# Altium schematic sheet [03] - 28PINS SCHEMATIC.SchDoc("[03] - 28PINS SCHEMATIC") (continued)

## Library data of the parts
C1 C3 C4 C7 C11 C13 C15-C17 C21 C22 C24 C26 C28-C33 = C2012X7R1H104K085AA: Multilayer Ceramic Capacitor, 0.1 uF, 50 V, ± 10%, X7R, 0805 [2012 Metric]; Manufacturer: TDK; Voltage Rating: 50V; Material: 4241ConductorMaterial; Width: 0.049inch; Voltage: 50V; Tolerance: 10%; Thickness: 0.037inch; Termination: SMD/SMT; Supplier Part Number 1: 445-7534-1-ND; Supplier 1: Digikey; Stock 1: 3235; Series: C; RoHS Compliant: Yes; RoHS: Yes; Reach SVHC Compliant: No; Pricing 1: 1 = 0.13, 10 = 0.091, 50 = 0.054, 100 = 0.0425, 500 = 0.03142, 1000 = 0.02601 (USD); Packaging: Tape and Reel; Package Quantity: 1; Number of Pins: 2; Mount: Surface Mount; Min Operating Temperature: -55°C; Max Operating Temperature: 125°C; Manufacturer Part Number: C2012X7R1H104K085AA; Library Name: 28Pins.SchLib; Length: 2mm; Lead Free: Lead Free; Height: 0.85mm; Dielectric Material: Ceramic; Dielectric: X7R; Depth: 1.25mm; ComponentLink6URL: https://datasheet.ciiva.com/24191/e412-c-24191447.pdf?src-supplier=Future+Electronics; ComponentLink6Description: https://datasheet.ciiva.com/24191/e412-c-24191447.pdf?src-supplier=Future+Electronics; ComponentLink5URL: https://datasheet.ciiva.com/6250/tdkmlcccaprange-6250824.pdf?src-supplier=Digikey; ComponentLink5Description: https://datasheet.ciiva.com/6250/tdkmlcccaprange-6250824.pdf?src-supplier=Digikey; ComponentLink4URL: https://datasheet.ciiva.com/6250/tdkmlcccaprange-6250821.pdf?src-supplier=Digikey; ComponentLink4Description: https://datasheet.ciiva.com/6250/tdkmlcccaprange-6250821.pdf?src-supplier=Digikey; ComponentLink3URL: https://datasheet.ciiva.com/28602/1571323-28602204.pdf?src-supplier=Element14; ComponentLink3Description: https://datasheet.ciiva.com/28602/1571323-28602204.pdf?src-supplier=Element14; ComponentLink2URL: https://datasheet.ciiva.com/23803/1796654-23803035.pdf?src-supplier=Newark; ComponentLink2Description: https://datasheet.ciiva.com/23803/1796654-23803035.pdf?src-supplier=Newark; ComponentLink1URL: https://datasheet.ciiva.com/11788/0900766b80c1bb00-11788128.pdf?src-supplier=Rs; ComponentLink1Description: https://datasheet.ciiva.com/11788/0900766b80c1bb00-11788128.pdf?src-supplier=Rs; Component Kind: Standard; Case Code (Metric): 2012; Case Code (Imperial): 0805; Case/Package: 0805; Capacitance: 100nF
C2 C12 C14 C18-C20 C23 = C2012X5R1C106M085AC: Multilayer Ceramic Capacitor, 10 uF, 16 V, ± 20%, X5R, 0805 [2012 Metric]; Manufacturer: TDK; Voltage Rating (DC): 16V; Material: 4241ConductorMaterial; Capacitance: 10uF; Width: 1.25mm; Weight: 0.000194oz; Voltage Rating: 16V; Voltage: 16V; Tolerance: 20%; Termination: SMD/SMT; Supplier Part Number 1: 445-7645-1-ND; Supplier 1: Digikey; Stock 1: 27909; Series: C; RoHS Compliant: Yes; RoHS: Yes; Reach SVHC Compliant: Unknown; Pricing 1: 1 = 0.26, 10 = 0.169, 50 = 0.1066, 100 = 0.0853, 500 = 0.0616, 1000 = 0.05449 (USD); Packaging: Tape and Reel; Package Quantity: 4000; Number of Pins: 2; Mount: Surface Mount; Min Operating Temperature: -55°C; Max Operating Temperature: 85°C; Manufacturer Part Number: C2012X5R1C106M085AC; Library Name: 28Pins.SchLib; Length: 2mm; Lead Free: Lead Free; Height: 0.85mm; Dielectric Material: Ceramic; Dielectric: X5R; Depth: 1.25mm; Construction: Flat; ComponentLink7URL: https://datasheet.ciiva.com/22725/1913358-22725557.pdf?src-supplier=Element14; ComponentLink7Description: https://datasheet.ciiva.com/22725/1913358-22725557.pdf?src-supplier=Element14; ComponentLink6URL: https://datasheet.ciiva.com/6290/tdkmlcccaprange-6290487.pdf?src-supplier=Digikey; ComponentLink6Description: https://datasheet.ciiva.com/6290/tdkmlcccaprange-6290487.pdf?src-supplier=Digikey; ComponentLink5URL: https://datasheet.ciiva.com/6290/tdkmlcccaprange-6290485.pdf?src-supplier=Digikey; ComponentLink5Description: https://datasheet.ciiva.com/6290/tdkmlcccaprange-6290485.pdf?src-supplier=Digikey; ComponentLink4URL: https://datasheet.ciiva.com/23803/1796654-23803021.pdf?src-supplier=Newark; ComponentLink4Description: https://datasheet.ciiva.com/23803/1796654-23803021.pdf?src-supplier=Newark; ComponentLink3URL: https://datasheet.ciiva.com/16449/e412-c-16449591.pdf?src-supplier=Future+Electronics; ComponentLink3Description: https://datasheet.ciiva.com/16449/e412-c-16449591.pdf?src-supplier=Future+Electronics; ComponentLink2URL: https://datasheet.ciiva.com/11788/0900766b80c1bb00-11788108.pdf?src-supplier=Rs; ComponentLink2Description: https://datasheet.ciiva.com/11788/0900766b80c1bb00-11788108.pdf?src-supplier=Rs; ComponentLink1URL: https://datasheet.ciiva.com/24218/c2012x5r1c106m085ac-265002-24218340.pdf?src-supplier=Mouser; ComponentLink1Description: https://datasheet.ciiva.com/24218/c2012x5r1c106m085ac-265002-24218340.pdf?src-supplier=Mouser; Component Kind: Standard; Case Code (Metric): 2012; Case Code (Imperial): 0805; Case/Package: 0805
C5 C6 = EEE-1AA101WR: Aluminum Electrolytic Capacitor, 100 uF, 10 V, ± 20%, 1000 hours @ 85°C, Radial Can - SMD; Manufacturer: Panasonic; Voltage Rating (DC): 10V; Ripple Current (AC): 50mA; Leakage Current: 10uA; Dissipation Factor: 30%; Voltage Rating: 10V; Tolerance: 20%; Termination: SMD/SMT; Supplier Part Number 1: PCE3867CT-ND; Supplier 1: Digikey; Stock 1: 10133; Series: S; RoHS Compliant: Yes; RoHS: Yes; Ripple Current: 50mA; Reach SVHC Compliant: Unknown; Ratings: AEC-Q200; Radiation Hardening: No; Pricing 1: 1 = 0.35, 10 = 0.228, 50 = 0.168, 100 = 0.1368, 500 = 0.108 (USD); Packaging: Tape and Reel; Package Quantity: 1000; Mount: Surface Mount,PCB; Min Operating Temperature: -40°C; Max Operating Temperature: 85°C; Manufacturer Part Number: EEE-1AA101WR; Life (Hours): 1000Hour; Library Name: 28Pins.SchLib; Length: 5.5mm; Lead Free: Lead Free; Height: 5.4mm; Diameter: 5mm; Construction: Cylindrical; ComponentLink8URL: https://datasheet.ciiva.com/30075/11aba0000ce2-30075303.pdf?src-supplier=Onlinecomponents.com; ComponentLink8Description: https://datasheet.ciiva.com/30075/11aba0000ce2-30075303.pdf?src-supplier=Onlinecomponents.com; ComponentLink7URL: https://datasheet.ciiva.com/2983/aba0000ce2-2983906.pdf?src-supplier=Avnet; ComponentLink7Description: https://datasheet.ciiva.com/2983/aba0000ce2-2983906.pdf?src-supplier=Avnet; ComponentLink6URL: https://datasheet.ciiva.com/16427/aba0000ce2-16427544.pdf?src-supplier=Future+Electronics; ComponentLink6Description: https://datasheet.ciiva.com/16427/aba0000ce2-16427544.pdf?src-supplier=Future+Electronics; ComponentLink5URL: https://datasheet.ciiva.com/24027/aba0000ce2-40801-24027541.pdf?src-supplier=Mouser; ComponentLink5Description: https://datasheet.ciiva.com/24027/aba0000ce2-40801-24027541.pdf?src-supplier=Mouser; ComponentLink4URL: https://datasheet.ciiva.com/11673/aba0000ce2-11673882.pdf?src-supplier=Avnet; ComponentLink4Description: https://datasheet.ciiva.com/11673/aba0000ce2-11673882.pdf?src-supplier=Avnet; ComponentLink3URL: https://datasheet.ciiva.com/14605/70255710-14605689.pdf?src-supplier=Allied+Electronics; ComponentLink3Description: https://datasheet.ciiva.com/14605/70255710-14605689.pdf?src-supplier=Allied+Electronics; ComponentLink2URL: https://datasheet.ciiva.com/14598/70255710-14598233.pdf?src-supplier=Allied+Electronics; ComponentLink2Description: https://datasheet.ciiva.com/14598/70255710-14598233.pdf?src-supplier=Allied+Electronics; ComponentLink1URL: https://datasheet.ciiva.com/26945/getdatasheetpartid-169213-26945071.pdf?src-supplier=Verical; ComponentLink1Description: https://datasheet.ciiva.com/26945/getdatasheetpartid-169213-26945071.pdf?src-supplier=Verical; Component Kind: Standard; Case/Package: Radial; Capacitance: 100uF
C8 = C2012X5R1E105K125AA: CAP CER 1UF 25V X5R 0805; Manufacturer: TDK; Tolerance: 10%; Dielectric Material: Ceramic; Voltage Rating: 25V; Voltage: 25V; Termination: SMD/SMT; Supplier Part Number 1: 445-1419-1-ND; Supplier 1: Digikey; Stock 1: 54578; Series: C; RoHS Compliant: Yes; RoHS: Yes; Reach SVHC Compliant: No; Radiation Hardening: No; Pricing 1: 1 = 0.22, 10 = 0.141, 50 = 0.089, 100 = 0.0712, 500 = 0.05142, 1000 = 0.04548 (USD); Packaging: Tape and Reel; Number of Pins: 2; Mount: Surface Mount; Min Operating Temperature: -55°C; Max Operating Temperature: 85°C; Manufacturer Part Number: C2012X5R1E105K125AA; Library Name: 28Pins.SchLib; Length: 2mm; Lead Free: Lead Free; Height: 1.25mm; Dielectric: X5R; Depth: 1.25mm; Construction: Flat; ComponentLink4URL: https://datasheet.ciiva.com/16449/e412-c-16449595.pdf?src-supplier=Future+Electronics; ComponentLink4Description: https://datasheet.ciiva.com/16449/e412-c-16449595.pdf?src-supplier=Future+Electronics; ComponentLink3URL: https://datasheet.ciiva.com/15192/getdatasheetpartid-726707-15192140.pdf?src-supplier=Verical; ComponentLink3Description: https://datasheet.ciiva.com/15192/getdatasheetpartid-726707-15192140.pdf?src-supplier=Verical; ComponentLink2URL: https://datasheet.ciiva.com/28602/1744655-28602171.pdf?src-supplier=Element14; ComponentLink2Description: https://datasheet.ciiva.com/28602/1744655-28602171.pdf?src-supplier=Element14; ComponentLink1URL: https://datasheet.ciiva.com/11787/0900766b80c1bb00-11787608.pdf?src-supplier=Rs; ComponentLink1Description: https://datasheet.ciiva.com/11787/0900766b80c1bb00-11787608.pdf?src-supplier=Rs; Component Kind: Standard; Case Code (Metric): 2012; Case Code (Imperial): 0805; Case/Package: 0805; Capacitance: 1uF
C9 C10 C25 C27 = C0805C180J5GACTU: Multilayer Ceramic Capacitor, 18 pF, 50 V, ± 5%, C0G (NP0), 0805 [2012 Metric]; Manufacturer: KEMET; Voltage Rating (DC): 50V; Material: 4241ConductorMaterial; Dissipation Factor: 0.1%; Capacitance: 18pF; Width: 0.049inch; Voltage Rating (AC): 50V; Voltage Rating: 50V; Voltage: 50V; Tolerance: 5%; Thickness: 0.035inch; Termination: SMD/SMT; Supplier Part Number 1: 399-C0805C180J5GAC7800CT-ND; Supplier 1: Digikey; Stock 1: 144471; Series: C; RoHS Compliant: Yes; RoHS: Yes; Reach SVHC Compliant: No; Radiation Hardening: No; Pricing 1: 1 = 0.11, 10 = 0.075, 50 = 0.045, 100 = 0.0355, 500 = 0.02618, 1000 = 0.02168 (USD); Packaging: Tape and Reel; Package Quantity: 1; Number of Pins: 2; Mount: Surface Mount,PCB; Min Operating Temperature: -55°C; Max Operating Temperature: 125°C; Manufacturer Part Number: C0805C180J5GACTU; Library Name: 28Pins.SchLib; Length: 2mm; Lead Pitch: 0.75mm; Lead Free: 5697LeadFree; Height: 0.78mm; Dielectric: C0G; Depth: 1.25mm; Construction: Flat; ComponentLink3URL: https://datasheet.ciiva.com/26847/getdatasheetpartid-385668-26847862.pdf?src-supplier=Verical; ComponentLink3Description: https://datasheet.ciiva.com/26847/getdatasheetpartid-385668-26847862.pdf?src-supplier=Verical; ComponentLink2URL: https://datasheet.ciiva.com/26923/getdatasheetpartid-759702-26923980.pdf?src-supplier=Verical; ComponentLink2Description: https://datasheet.ciiva.com/26923/getdatasheetpartid-759702-26923980.pdf?src-supplier=Verical; ComponentLink1URL: https://datasheet.ciiva.com/22746/1769375-22746770.pdf?src-supplier=Element14; ComponentLink1Description: https://datasheet.ciiva.com/22746/1769375-22746770.pdf?src-supplier=Element14; Component Kind: Standard; Case Code (Metric): 2012; Case Code (Imperial): 0805; Case/Package: 0805
D1-D3 = SML-311DTT86: LED Uni-Color Orange 2-Pin Chip 0603(1608Metric) T/R; Manufacturer: Rohm; Wavelength: 611nm; Viewing Angle: 140°; Test Current: 2mA; Number of LEDs: 1; Luminous Intensity: 2.5mcd; Lens Transparency: Clear; Lens Style: Rectangular,Diffused,Colorless,Clear; Lens Color: Colorless,Clear; Forward Voltage: 1.8V; Dominant Wavelength: 605nm; Width: 0.8mm; Supplier Part Number 1: 511-1303-1-ND; Supplier 1: Digikey; Stock 1: 25516; Series: -; RoHS Compliant: Yes; RoHS: Yes; Radiation Hardening: No; Pricing 1: 1 = 0.39, 10 = 0.257, 100 = 0.1717, 500 = 0.11878, 1000 = 0.09898 (USD); Packaging: Tape and Reel; Package Quantity: 1; Number of Pins: 2; Mount: Surface Mount; Min Operating Temperature: -30°C; Max Operating Temperature: 85°C; Manufacturer Part Number: SML-311DTT86; Library Name: 28Pins.SchLib; Length: 1.6mm; Lead Free: Lead Free; Illumination Color: Orange; Height: 0.9mm; Forward Current: 2mA; ComponentLink5URL: https://datasheet.ciiva.com/2110/sml-311series-2110840.pdf?src-supplier=Digikey; ComponentLink5Description: https://datasheet.ciiva.com/2110/sml-311series-2110840.pdf?src-supplier=Digikey; ComponentLink4URL: https://datasheet.ciiva.com/2110/sml-311series-2110839.pdf?src-supplier=Digikey; ComponentLink4Description: https://datasheet.ciiva.com/2110/sml-311series-2110839.pdf?src-supplier=Digikey; ComponentLink3URL: https://datasheet.ciiva.com/2110/sml-311series-2110838.pdf?src-supplier=Digikey; ComponentLink3Description: https://datasheet.ciiva.com/2110/sml-311series-2110838.pdf?src-supplier=Digikey; ComponentLink2URL: https://datasheet.ciiva.com/16388/sml-31-16388237.pdf?src-supplier=Future+Electronics; ComponentLink2Description: https://datasheet.ciiva.com/16388/sml-31-16388237.pdf?src-supplier=Future+Electronics; ComponentLink1URL: https://datasheet.ciiva.com/11774/0900766b80df3a77-11774225.pdf?src-supplier=Rs; ComponentLink1Description: https://datasheet.ciiva.com/11774/0900766b80df3a77-11774225.pdf?src-supplier=Rs; Component Kind: Standard; Color: Orange; Case Code (Metric): 1608; Case Code (Imperial): 0603; Case/Package: 0603
D4 = APT1608LZGCK: Green 525nm LED Indication - Discrete 2.65V 0603 (1608 Metric); Manufacturer: Kingbright; Viewing Angle: 120°; Test Current: 2mA; Luminous Intensity: 50mcd; Lens Transparency: Clear; Lens Color: Colorless; Width: 0.8mm; Supplier Part Number 1: 754-1934-1-ND; Supplier 1: Digikey; Stock 1: 73571; Series: HELI2; RoHS Compliant: Yes; RoHS: Yes; Pricing 1: 1 = 0.55, 10 = 0.364, 100 = 0.241, 1000 = 0.1861 (USD); Packaging: Cut Tape; Mount: Surface Mount; Manufacturer Part Number: APT1608LZGCK; Library Name: 28Pins.SchLib; Length: 1.6mm; Height: 0.75mm; Forward Voltage: 2.65V; Dominant Wavelength: 525nm; ComponentLink2URL: http://www.kingbrightusa.com/images/catalog/SPEC/APT1608LZGCK.pdf?src-supplier=Digikey; ComponentLink2Description: http://www.kingbrightusa.com/images/catalog/SPEC/APT1608LZGCK.pdf?src-supplier=Digikey; ComponentLink1URL: http://www.mouser.com/ds/2/216/APT1608LZGCK-535830.pdf?src-supplier=Mouser; ComponentLink1Description: http://www.mouser.com/ds/2/216/APT1608LZGCK-535830.pdf?src-supplier=Mouser; Component Kind: Standard; Color: Green; Case Code (Metric): 1608; Case Code (Imperial): 0603; Case/Package: 0603
D5 D6 = BAT54HT1G: Small Signal Schottky Diode, Single, 30 V, 200 Ma, 800 Mv, 600 Ma, 150 C; Manufacturer: ON Semiconductor / Fairchild; Voltage Rating (DC): 30V; Reverse Recovery Time-Max: 5ns; Power Dissipation: 200mW; Polarity: Standard; Peak Non-Repetitive Surge Current: 600mA; Output Current: 200mA; Max Reverse Leakage Current: 2uA; Forward Voltage: 800mV; Capacitance: 10pF; Width: 1.35mm; Supplier Part Number 1: BAT54HT1GOSCT-ND; Supplier 1: Digikey; Stock 1: 8229; Series: -; RoHS Compliant: Yes; RoHS: Yes; Reverse Voltage: 30V; Reverse Recovery Time: 5ns; Recovery Time: 5ns; Reach SVHC Compliant: No; Radiation Hardening: No; Pricing 1: 1 = 0.2, 10 = 0.138, 100 = 0.0675, 500 = 0.05634, 1000 = 0.03915 (USD); Packaging: Tape and Reel; Package Quantity: 1; Number of Pins: 2; Mount: Surface Mount; Min Operating Temperature: -55°C; Max Surge Current: 600mA; Max Reverse Voltage (DC): 30V; Max Reverse Current: 2uA; Max Repetitive Reverse Voltage (Vrrm): 30V; Max Operating Temperature: 150°C; Max Forward Surge Current (Ifsm): 600mA; Manufacturer Part Number: BAT54HT1G; Library Name: 28Pins.SchLib; Length: 1.8mm; Lead Free: Lead Free; Height: 1mm; Forward Current: 200mA; Current Rating: 200mA; Contact Plating: Tin,Matte; ComponentLink18URL: https://datasheet.ciiva.com/48686/001262925-da-01-en-diode-schottky-30v-bat54ht1g-sod-323-fsc-48686266.pdf?src-supplier=Conrad; ComponentLink18Description: https://datasheet.ciiva.com/48686/001262925-da-01-en-diode-schottky-30v-bat54ht1g-sod-323-fsc-48686266.pdf?src-supplier=Conrad; ComponentLink17URL: https://datasheet.ciiva.com/17020/bat54ht1g-17020445.pdf?src-supplier=Future+Electronics; ComponentLink17Description: https://datasheet.ciiva.com/17020/bat54ht1g-17020445.pdf?src-supplier=Future+Electronics; ComponentLink16URL: https://datasheet.ciiva.com/1180/bat54ht1-d-1180251.pdf?src-supplier=Element14; ComponentLink16Description: https://datasheet.ciiva.com/1180/bat54ht1-d-1180251.pdf?src-supplier=Element14; ComponentLink15URL: https://datasheet.ciiva.com/1180/bat54ht1-d-1180252.pdf?src-supplier=Element14; ComponentLink15Description: https://datasheet.ciiva.com/1180/bat54ht1-d-1180252.pdf?src-supplier=Element14; ComponentLink14URL: https://datasheet.ciiva.com/46429/1727620-46429206.pdf?src-supplier=Element14; ComponentLink14Description: https://datasheet.ciiva.com/46429/1727620-46429206.pdf?src-supplier=Element14; ComponentLink13URL: https://datasheet.ciiva.com/915/bat54ht1-d-915443.pdf?src-supplier=Digikey; ComponentLink13Description: https://datasheet.ciiva.com/915/bat54ht1-d-915443.pdf?src-supplier=Digikey; ComponentLink12URL: https://datasheet.ciiva.com/8303/bat54ht1g-8303645.pdf?src-supplier=Avnet; ComponentLink12Description: https://datasheet.ciiva.com/8303/bat54ht1g-8303645.pdf?src-supplier=Avnet; ComponentLink11URL: https://datasheet.ciiva.com/910/bat54ht1g-910497.pdf?src-supplier=Digikey; ComponentLink11Description: https://datasheet.ciiva.com/910/bat54ht1g-910497.pdf?src-supplier=Digikey; ComponentLink10URL: https://datasheet.ciiva.com/8294/bat54ht1-d-8294645.pdf?src-supplier=Avnet; ComponentLink10Description: https://datasheet.ciiva.com/8294/bat54ht1-d-8294645.pdf?src-supplier=Avnet; ComponentLink9URL: https://datasheet.ciiva.com/910/bat54ht1g-910498.pdf?src-supplier=Digikey; ComponentLink9Description: https://datasheet.ciiva.com/910/bat54ht1g-910498.pdf?src-supplier=Digikey; ComponentLink8URL: https://datasheet.ciiva.com/910/bat54ht1g-910499.pdf?src-supplier=Digikey; ComponentLink8Description: https://datasheet.ciiva.com/910/bat54ht1g-910499.pdf?src-supplier=Digikey; ComponentLink7URL: https://datasheet.ciiva.com/915/bat54ht1-d-915444.pdf?src-supplier=Digikey; ComponentLink7Description: https://datasheet.ciiva.com/915/bat54ht1-d-915444.pdf?src-supplier=Digikey; ComponentLink6URL: https://datasheet.ciiva.com/915/bat54ht1-d-915445.pdf?src-supplier=Digikey; ComponentLink6Description: https://datasheet.ciiva.com/915/bat54ht1-d-915445.pdf?src-supplier=Digikey; ComponentLink5URL: https://datasheet.ciiva.com/1240/1747983-1240762.pdf?src-supplier=Newark; ComponentLink5Description: https://datasheet.ciiva.com/1240/1747983-1240762.pdf?src-supplier=Newark; ComponentLink4URL: https://datasheet.ciiva.com/34301/806549-34301562.pdf?src-supplier=Jameco; ComponentLink4Description: https://datasheet.ciiva.com/34301/806549-34301562.pdf?src-supplier=Jameco; ComponentLink3URL: https://datasheet.ciiva.com/30479/0900766b811a63a9-30479442.pdf?src-supplier=Rs; ComponentLink3Description: https://datasheet.ciiva.com/30479/0900766b811a63a9-30479442.pdf?src-supplier=Rs; ComponentLink2URL: https://datasheet.ciiva.com/4452/bat54ht1g-104602-4452889.pdf?src-supplier=Mouser; ComponentLink2Description: https://datasheet.ciiva.com/4452/bat54ht1g-104602-4452889.pdf?src-supplier=Mouser; ComponentLink1URL: https://datasheet.ciiva.com/4714/bat54ht1-d-72881-4714706.pdf?src-supplier=Mouser; ComponentLink1Description: https://datasheet.ciiva.com/4714/bat54ht1-d-72881-4714706.pdf?src-supplier=Mouser; Component Kind: Standard; Breakdown Voltage: 30V; Average Rectified Current: 200mA
FB1-FB3 = MPZ2012S601AT000: Ferrite Beads Multi-Layer Power 600Ohm 25% 100MHz 2A 100mOhm DCR 0805 T/R; Manufacturer: TDK; Series Resistance: 100mR; Number of Lines: 1; Impedance: 600R; Width: 0.049inch; Tolerance: 25%; Test Frequency: 100MHz; Supplier Part Number 1: 1131051; Supplier 1: Future Electronics; Stock 1: 524000; Series: MPZ; RoHS Compliant: Yes; RoHS: Yes; RMS Current (Irms): 2A; Reach SVHC Compliant: No; Pricing 1: 4000 = 0.0289, 12000 = 0.0278, 20000 = 0.0273, 40000 = 0.0267, 80000 = 0.0257 (USD); Packaging: Tape and Reel; Package Quantity: 1; Mount: Surface Mount; Min Operating Temperature: -55°C; Max Operating Temperature: 125°C; Max DC Current: 2A; Max Current Rating: 2A; Manufacturer Part Number: MPZ2012S601AT000; Library Name: 28Pins.SchLib; Length: 0.079inch; Lead Free: Lead Free; Height: 0.041inch; DC Resistance (DCR): 100mR; Current Rating: 2A; Core Material: Ferrite; ComponentLink3URL: https://datasheet.ciiva.com/46634/beads-commercial-power-mpz-en-46634606.pdf?src-supplier=Digikey; ComponentLink3Description: https://datasheet.ciiva.com/46634/beads-commercial-power-mpz-en-46634606.pdf?src-supplier=Digikey; ComponentLink2URL: https://datasheet.ciiva.com/46634/beads-commercial-power-mpz-en-46634377.pdf?src-supplier=Digikey; ComponentLink2Description: https://datasheet.ciiva.com/46634/beads-commercial-power-mpz-en-46634377.pdf?src-supplier=Digikey; ComponentLink1URL: https://datasheet.ciiva.com/46634/beads-commercial-power-mpz-en-46634335.pdf?src-supplier=Digikey; ComponentLink1Description: https://datasheet.ciiva.com/46634/beads-commercial-power-mpz-en-46634335.pdf?src-supplier=Digikey; Component Kind: Standard; Case Code (Metric): 2012; Case Code (Imperial): 0805; Case/Package: 0805
FID1-FID4 = FID_1MM: Fiducial 40mil diameter, 120mil mask; Library Name: 28Pins.SchLib
J1 = M20-9980245: Conn Unshrouded Header HDR 4 POS 2.54mm Solder ST Thru-Hole Bag; Manufacturer: Harwin; Number of Positions: 4; Max Current Rating: 3A; Mated Stacking Heights: 16.54mm; Gender: Male; Contact Resistance: 25mR; Weight: 0.008395oz; Termination: Solder; Supplier Part Number 1: 952-2119-ND; Supplier 1: Digikey; Stock 1: 19359; Series: M20; RoHS Compliant: Yes; RoHS: Yes; Radiation Hardening: No; Pricing 1: 1 = 0.28, 10 = 0.242, 100 = 0.1881, 500 = 0.1612, 1000 = 0.13433, 5000 = 0.11514, 10000 = 0.10746 (USD); Pitch: 2.54mm; Packaging: Bulk; Orientation: Straight; Number of Rows: 2; Number of Contacts: 4; Mount: Through Hole; Min Operating Temperature: -40°C; Max Operating Temperature: 105°C; Manufacturer Part Number: M20-9980245; Library Name: 28Pins.SchLib; Length: 5.08mm; Insulation Material: Nylon; Insulation Color: Black; Housing Material: Nylon; Housing Color: Black; Flammability Rating: UL94 V-0; Fastening Type: Pull; Depth: 5.08mm; Contact Type: Male Pin; Contact Shape: Square; Contact Plating: Gold; Contact Material: Brass; Contact Finish - Mating: GOLD; ComponentLink2URL: https://datasheet.ciiva.com/26899/getdatasheetpartid-721707-26899431.pdf?src-supplier=Verical; ComponentLink2Description: https://datasheet.ciiva.com/26899/getdatasheetpartid-721707-26899431.pdf?src-supplier=Verical; ComponentLink1URL: https://datasheet.ciiva.com/6814/m20-998-6814779.pdf?src-supplier=Digikey; ComponentLink1Description: https://datasheet.ciiva.com/6814/m20-998-6814779.pdf?src-supplier=Digikey; Component Kind: Standard
J2 J6 = 67997-106HLF: Conn Unshrouded Header HDR 6 POS 2.54mm Solder ST Top Entry Thru-Hole BergStik® Bulk; Manufacturer: Amphenol ICC / FCI; Voltage Rating: 1.5kV; Row Spacing: 0.1inch; Number of Positions: 6; Insulation Resistance: 5GR; Current Rating: 3A; Contact Post Length: 3.05mm; Termination: Through Hole; Supplier Part Number 1: 609-3393-ND; Supplier 1: Digikey; Stock 1: 110; Series: 67997; RoHS: No; Reach SVHC Compliant: No; Radiation Hardening: No; Pricing 1: 1 = 0.63, 10 = 0.547, 100 = 0.4511, 500 = 0.39228, 1000 = 0.32363, 5000 = 0.28441, 10000 = 0.26479 (USD); Pitch: 2.54mm; Packaging: Bulk; Package Quantity: 100; Orientation: Straight; Number of Rows: 2; Number of Contacts: 6; Mount: Through Hole; Min Operating Temperature: -65°C; Max Voltage Rating (AC): 1.5kV; Max Operating Temperature: 125°C; Manufacturer Part Number: 67997-106HLF; Library Name: 28Pins.SchLib; Length: 7.62mm; Housing Material: Thermoplastic; Housing Color: Black; Flammability Rating: UL94 V-0; Depth: 4.83mm; Contact Type: Male Pin; Contact Plating: Gold,GXT; Contact Mating Length: 0.23inch; Contact Material: Bronze; Contact Gender: Male; ComponentLink11URL: https://cdn.amphenol-icc.com/media/wysiwyg/files/drawing/67996.pdf?src-supplier=Heilind+Electronics; ComponentLink11Description: https://cdn.amphenol-icc.com/media/wysiwyg/files/drawing/67996.pdf?src-supplier=Heilind+Electronics; ComponentLink10URL: https://cdn.amphenol-icc.com/media/wysiwyg/files/drawing/67996.pdf?src-supplier=TTI; ComponentLink10Description: https://cdn.amphenol-icc.com/media/wysiwyg/files/drawing/67996.pdf?src-supplier=TTI; ComponentLink9URL: https://cdn.amphenol-icc.com/media/wysiwyg/files/drawing/67996.pdf?src-supplier=Onlinecomponents.com; ComponentLink9Description: https://cdn.amphenol-icc.com/media/wysiwyg/files/drawing/67996.pdf?src-supplier=Onlinecomponents.com; ComponentLink8URL: https://cdn.amphenol-icc.com/media/wysiwyg/files/drawing/67996.pdf?src-supplier=Allied+Electronics; ComponentLink8Description: https://cdn.amphenol-icc.com/media/wysiwyg/files/drawing/67996.pdf?src-supplier=Allied+Electronics; ComponentLink7URL: http://www.farnell.com/datasheets/1876884.pdf?src-supplier=Newark; ComponentLink7Description: http://www.farnell.com/datasheets/1876884.pdf?src-supplier=Newark; ComponentLink6URL: https://cdn.amphenol-icc.com/media/wysiwyg/files/drawing/67996.pdf?src-supplier=Mouser; ComponentLink6Description: https://cdn.amphenol-icc.com/media/wysiwyg/files/drawing/67996.pdf?src-supplier=Mouser; ComponentLink5URL: https://cdn.amphenol-icc.com/media/wysiwyg/files/drawing/67996.pdf?src-supplier=Avnet; ComponentLink5Description: https://cdn.amphenol-icc.com/media/wysiwyg/files/drawing/67996.pdf?src-supplier=Avnet; ComponentLink4URL: https://datasheet.octopart.com/67997-106HLF-Amphenol-Communications-Solutions-datasheet-36854132.pdf?src-supplier=Farnell; ComponentLink4Description: https://datasheet.octopart.com/67997-106HLF-Amphenol-Communications-Solutions-datasheet-36854132.pdf?src-supplier=Farnell; ComponentLink3URL: https://datasheet.octopart.com/67997-106HLF-Areva-datasheet-118652.pdf?src-supplier=Digikey; ComponentLink3Description: https://datasheet.octopart.com/67997-106HLF-Areva-datasheet-118652.pdf?src-supplier=Digikey; ComponentLink2URL: http://components.arrow.com/part/dataview/41913658S7633350N8314?src-supplier=Arrow+Electronics; ComponentLink2Description: http://components.arrow.com/part/dataview/41913658S7633350N8314?src-supplier=Arrow+Electronics; ComponentLink1URL: http://uk.rs-online.com/webdocs/1450/0900766b81450b8b.pdf?src-supplier=Rs; ComponentLink1Description: http://uk.rs-online.com/webdocs/1450/0900766b81450b8b.pdf?src-supplier=Rs; Component Kind: Standard; Color: Black
J3 = 10118193-0001LF: FCI Right Angle Surface Mount Mount Female USB Connector; 100 V ac; 1.8A; Manufacturer: Amphenol ICC / FCI; Voltage Rating: 100V; Pitch: 0.65mm; Number of Ports: 1; Number of Contacts: 5; Insulation Resistance: 1GR; Connector Type: USB,Receptacle; Termination: Solder; Supplier Part Number 1: 609-4616-1-ND; Supplier 1: Digikey; Stock 1: 238085; Series: -; RoHS: No; Radiation Hardening: No; Pricing 1: 1 = 0.46, 10 = 0.404, 25 = 0.3696, 50 = 0.3478, 100 = 0.3334, 250 = 0.30436, 500 = 0.28988 (USD); Packaging: Tape and Reel; Package Quantity: 1200; Orientation: Right Angle; Number of Pins: 5; Mount: Surface Mount,Horizontal; Min Operating Temperature: -55°C; Max Voltage Rating (AC): 100V; Max Operating Temperature: 85°C; Manufacturer Part Number: 10118193-0001LF; Library Name: 28Pins.SchLib; Length: 7.86mm; Housing Material: Polymer; Gender: Female; Flammability Rating: UL94 V-0; Features: Shielded; Depth: 5.67mm; Current Rating: 1.8A; Contact Plating: Gold; Contact Material: Copper Alloy; ComponentLink15URL: https://cdn.amphenol-icc.com/media/wysiwyg/files/documentation/datasheet/inputoutput/io_usb_micro.pdf?src-supplier=Heilind+Electronics; ComponentLink15Description: https://cdn.amphenol-icc.com/media/wysiwyg/files/documentation/datasheet/inputoutput/io_usb_micro.pdf?src-supplier=Heilind+Electronics; ComponentLink14URL: https://datasheet.octopart.com/10118193-0001LF-Amphenol-Communications-Solutions-datasheet-11792339.pdf?src-supplier=Electro+Sonic; ComponentLink14Description: https://datasheet.octopart.com/10118193-0001LF-Amphenol-Communications-Solutions-datasheet-11792339.pdf?src-supplier=Electro+Sonic; ComponentLink13URL: https://cdn.amphenol-icc.com/media/wysiwyg/files/documentation/datasheet/inputoutput/io_usb_micro.pdf?src-supplier=TTI; ComponentLink13Description: https://cdn.amphenol-icc.com/media/wysiwyg/files/documentation/datasheet/inputoutput/io_usb_micro.pdf?src-supplier=TTI; ComponentLink12URL: https://datasheet.octopart.com/10118193-0001LF-Amphenol-Communications-Solutions-datasheet-55953155.pdf?src-supplier=TME; ComponentLink12Description: https://datasheet.octopart.com/10118193-0001LF-Amphenol-Communications-Solutions-datasheet-55953155.pdf?src-supplier=TME; ComponentLink11URL: https://cdn.amphenol-icc.com/media/wysiwyg/files/documentation/datasheet/inputoutput/io_usb_micro.pdf?src-supplier=Verical; ComponentLink11Description: https://cdn.amphenol-icc.com/media/wysiwyg/files/documentation/datasheet/inputoutput/io_usb_micro.pdf?src-supplier=Verical; ComponentLink10URL: https://cdn.amphenol-icc.com/media/wysiwyg/files/documentation/datasheet/inputoutput/io_usb_micro.pdf?src-supplier=Onlinecomponents.com; ComponentLink10Description: https://cdn.amphenol-icc.com/media/wysiwyg/files/documentation/datasheet/inputoutput/io_usb_micro.pdf?src-supplier=Onlinecomponents.com; ComponentLink9URL: https://cdn.amphenol-icc.com/media/wysiwyg/files/documentation/datasheet/inputoutput/io_usb_micro.pdf?src-supplier=Arrow+Electronics; ComponentLink9Description: https://cdn.amphenol-icc.com/media/wysiwyg/files/documentation/datasheet/inputoutput/io_usb_micro.pdf?src-supplier=Arrow+Electronics; ComponentLink8URL: https://datasheet.octopart.com/10118193-0001LF-Amphenol-Communications-Solutions-datasheet-96019471.pdf?src-supplier=Element14; ComponentLink8Description: https://datasheet.octopart.com/10118193-0001LF-Amphenol-Communications-Solutions-datasheet-96019471.pdf?src-supplier=Element14; ComponentLink7URL: https://cdn.amphenol-icc.com/media/wysiwyg/files/documentation/datasheet/inputoutput/io_usb_micro.pdf?src-supplier=Rs; ComponentLink7Description: https://cdn.amphenol-icc.com/media/wysiwyg/files/documentation/datasheet/inputoutput/io_usb_micro.pdf?src-supplier=Rs; ComponentLink6URL: https://cdn.amphenol-icc.com/media/wysiwyg/files/documentation/datasheet/inputoutput/io_usb_micro.pdf?src-supplier=Allied+Electronics; ComponentLink6Description: https://cdn.amphenol-icc.com/media/wysiwyg/files/documentation/datasheet/inputoutput/io_usb_micro.pdf?src-supplier=Allied+Electronics; ComponentLink5URL: https://cdn.amphenol-icc.com/media/wysiwyg/files/documentation/datasheet/inputoutput/io_usb_micro.pdf?src-supplier=Mouser; ComponentLink5Description: https://cdn.amphenol-icc.com/media/wysiwyg/files/documentation/datasheet/inputoutput/io_usb_micro.pdf?src-supplier=Mouser; ComponentLink4URL: https://cdn.amphenol-icc.com/media/wysiwyg/files/documentation/datasheet/inputoutput/io_usb_micro.pdf?src-supplier=Digikey; ComponentLink4Description: https://cdn.amphenol-icc.com/media/wysiwyg/files/documentation/datasheet/inputoutput/io_usb_micro.pdf?src-supplier=Digikey; ComponentLink3URL: http://www.amphenol-icc.com/media/wysiwyg/files/drawing/10118193.pdf?src-supplier=Digikey; ComponentLink3Description: http://www.amphenol-icc.com/media/wysiwyg/files/drawing/10118193.pdf?src-supplier=Digikey; ComponentLink2URL: https://cdn.amphenol-icc.com/media/wysiwyg/files/documentation/datasheet/inputoutput/io_usb_micro.pdf?src-supplier=Avnet; ComponentLink2Description: https://cdn.amphenol-icc.com/media/wysiwyg/files/documentation/datasheet/inputoutput/io_usb_micro.pdf?src-supplier=Avnet; ComponentLink1URL: http://uk.rs-online.com/webdocs/1450/0900766b814507f1.pdf?src-supplier=Rs; ComponentLink1Description: http://uk.rs-online.com/webdocs/1450/0900766b814507f1.pdf?src-supplier=Rs; Component Kind: Standard; Color: Black
J4 = 68001-204HLF: Conn Unshrouded Header HDR 4 POS 2.54mm Solder ST Thru-Hole Bag; Manufacturer: Amphenol ICC / FCI; Voltage Rating: 1.5kV; Number of Positions: 4; Insulation Resistance: 5GR; Current Rating: 3A; Contact Post Length: 3.05mm; Termination: Solder; Supplier Part Number 1: 609-3402-ND; Supplier 1: Digikey; Stock 1: 14591; Series: BERGSTIK® II, MezzSelect™, Basics+; RoHS: No; Radiation Hardening: No; Pricing 1: 1 = 0.48, 10 = 0.407, 100 = 0.3162, 500 = 0.27106, 1000 = 0.22589, 5000 = 0.19362, 10000 = 0.18071 (USD); Pitch: 2.54mm; Packaging: Bulk; Package Quantity: 100; Orientation: Straight; Number of Rows: 1; Number of Contacts: 4; Mount: Through Hole; Min Operating Temperature: -65°C; Max Operating Temperature: 125°C; Manufacturer Part Number: 68001-204HLF; Library Name: 28Pins.SchLib; Housing Material: Thermoplastic; Flammability Rating: UL94 V-0; Contact Type: Male Pin; Contact Plating: Gold,GXT; Contact Mating Length: 0.23inch; Contact Material: Bronze; Contact Gender: Male; ComponentLink11URL: https://cdn.amphenol-icc.com/media/wysiwyg/files/drawing/68000.pdf?src-supplier=Heilind+Electronics; ComponentLink11Description: https://cdn.amphenol-icc.com/media/wysiwyg/files/drawing/68000.pdf?src-supplier=Heilind+Electronics; ComponentLink10URL: https://cdn.amphenol-icc.com/media/wysiwyg/files/drawing/68000.pdf?src-supplier=TTI; ComponentLink10Description: https://cdn.amphenol-icc.com/media/wysiwyg/files/drawing/68000.pdf?src-supplier=TTI; ComponentLink9URL: https://datasheet.octopart.com/68001-204HLF-Amphenol-Communications-Solutions-datasheet-154727322.pdf?src-supplier=Master+Electronics; ComponentLink9Description: https://datasheet.octopart.com/68001-204HLF-Amphenol-Communications-Solutions-datasheet-154727322.pdf?src-supplier=Master+Electronics; ComponentLink8URL: https://cdn.amphenol-icc.com/media/wysiwyg/files/drawing/68000.pdf?src-supplier=Allied+Electronics; ComponentLink8Description: https://cdn.amphenol-icc.com/media/wysiwyg/files/drawing/68000.pdf?src-supplier=Allied+Electronics; ComponentLink7URL: https://datasheet.octopart.com/68001-204HLF-Amphenol-Communications-Solutions-datasheet-100449246.pdf?src-supplier=Future+Electronics; ComponentLink7Description: https://datasheet.octopart.com/68001-204HLF-Amphenol-Communications-Solutions-datasheet-100449246.pdf?src-supplier=Future+Electronics; ComponentLink6URL: https://cdn.amphenol-icc.com/media/wysiwyg/files/drawing/68000.pdf?src-supplier=Mouser; ComponentLink6Description: https://cdn.amphenol-icc.com/media/wysiwyg/files/drawing/68000.pdf?src-supplier=Mouser; ComponentLink5URL: https://datasheet.octopart.com/68001-204HLF-Amphenol-Communications-Solutions-datasheet-41232361.pdf?src-supplier=Element14; ComponentLink5Description: https://datasheet.octopart.com/68001-204HLF-Amphenol-Communications-Solutions-datasheet-41232361.pdf?src-supplier=Element14; ComponentLink4URL: https://cdn.amphenol-icc.com/media/wysiwyg/files/drawing/68000.pdf?src-supplier=Avnet; ComponentLink4Description: https://cdn.amphenol-icc.com/media/wysiwyg/files/drawing/68000.pdf?src-supplier=Avnet; ComponentLink3URL: https://cdn.amphenol-icc.com/media/wysiwyg/files/drawing/68000.pdf?src-supplier=Digikey; ComponentLink3Description: https://cdn.amphenol-icc.com/media/wysiwyg/files/drawing/68000.pdf?src-supplier=Digikey; ComponentLink2URL: http://components.arrow.com/part/dataview/42735081S8853857N8314?src-supplier=Arrow+Electronics; ComponentLink2Description: http://components.arrow.com/part/dataview/42735081S8853857N8314?src-supplier=Arrow+Electronics; ComponentLink1URL: http://uk.rs-online.com/webdocs/1450/0900766b81450c68.pdf?src-supplier=Rs; ComponentLink1Description: http://uk.rs-online.com/webdocs/1450/0900766b81450c68.pdf?src-supplier=Rs; Component Kind: Standard; Color: Black
J5 J8 = 68000-103HLF: Conn Unshrouded Header HDR 3 POS 2.54mm Solder ST Top Entry Thru-Hole BergStik® Automotive Bulk; Manufacturer: Amphenol ICC / FCI; Voltage Rating: 1.5kV; Number of Positions: 3; Insulation Resistance: 5GR; Current Rating: 3A; Contact Post Length: 2.41mm; Termination: Through Hole; Supplier Part Number 1: 609-3461-ND; Supplier 1: Digikey; Stock 1: 0; Series: 68000; RoHS: No; Reach SVHC Compliant: No; Radiation Hardening: No; Pricing 1: 1 = 0.37, 10 = 0.317, 100 = 0.2462, 500 = 0.21104, 1000 = 0.17587, 5000 = 0.15074, 10000 = 0.14069 (USD); Pitch: 2.54mm; Packaging: Bulk; Package Quantity: 100; Orientation: Straight; Number of Rows: 1; Number of Contacts: 3; Min Operating Temperature: -65°C; Max Voltage Rating (AC): 1.5kV; Max Operating Temperature: 125°C; Manufacturer Part Number: 68000-103HLF; Library Name: 28Pins.SchLib; Length: 7.62mm; Housing Material: Thermoplastic; Housing Color: Black; Flammability Rating: UL94 V-0; Depth: 2.41mm; Contact Plating: Gold,GXT; Contact Mating Length: 5.84mm; Contact Material: Bronze; Contact Gender: Male; ComponentLink1URL: https://datasheet.ciiva.com/46392/1914865-46392021.pdf?src-supplier=Element14; ComponentLink1Description: https://datasheet.ciiva.com/46392/1914865-46392021.pdf?src-supplier=Element14; Component Kind: Standard
J7 J9 = PAD_WITH_HOLE: PAD_WITH_HOLE 4mmx1.5mm; Library Name: 28Pins.SchLib
J10 J13 = M20-7820842: 2.54mm (0.1") Pitch SIL Vertical PC Tail Socket Assembly, selective gold + tin, 8 contacts; Manufacturer: Harwin; Voltage Rating: 1kV; Number of Positions: 8; Mated Stacking Heights: 12.63mm; Gender: Female; Connector Type: Receptacle; Weight: 0.025397oz; Termination: Solder; Supplier Part Number 1: 952-1823-ND; Supplier 1: Digikey; Stock 1: 3519; Series: M20; RoHS Compliant: Yes; RoHS: Yes; Radiation Hardening: No; Pricing 1: 1 = 1.44, 10 = 1.215, 100 = 1.091, 500 = 0.89264, 1000 = 0.79346, 5000 = 0.69427, 10000 = 0.65956 (USD); Pitch: 2.54mm; Packaging: Rail/Tube; Number of Rows: 1; Number of Contacts: 8; Mount: Through Hole; Min Operating Temperature: -40°C; Max Operating Temperature: 105°C; Manufacturer Part Number: M20-7820842; Library Name: 28Pins.SchLib; Insulation Material: Nylon; Insulation Color: Black; Flammability Rating: UL94 V-0; Fastening Type: Pull; Contact Type: Female Socket; Contact Shape: Square; Contact Plating: Tin,Gold; Contact Material: Bronze; Contact Gender: Female; Contact Finish - Mating: GOLD; ComponentLink1URL: https://datasheet.ciiva.com/9480/m20-782-9480074.pdf?src-supplier=Avnet; ComponentLink1Description: https://datasheet.ciiva.com/9480/m20-782-9480074.pdf?src-supplier=Avnet; Component Kind: Standard; Case/Package: SIL
J11 = M20-7821046: 2.54mm (0.1") Pitch SIL Vertical PC Tail Socket Assembly, tin, 10 contacts M20-7821046; Manufacturer: Harwin; Voltage Rating: 1kV; Number of Positions: 10; Max Current Rating: 3A; Mating Cycles: 50; Mated Stacking Heights: 12.63mm; Insulation Resistance: 1MR; Hole Diameter: 0.8mm; Gender: Receptacle; Contact Resistance: 20mR; Termination: Solder; Supplier Part Number 1: 952-1847-ND; Supplier 1: Digikey; Stock 1: 3064; Series: M20; RoHS Compliant: Yes; RoHS: Yes; Reach SVHC Compliant: No; Radiation Hardening: No; Pricing 1: 1 = 1.46, 10 = 1.27, 100 = 1.1347, 500 = 0.94562, 1000 = 0.81052, 5000 = 0.71867, 10000 = 0.70246 (USD); Pitch: 2.54mm; Packaging: Rail/Tube; Package Quantity: 23; Orientation: Straight; Number of Rows: 1; Number of Contacts: 10; Mount: Through Hole; Min Operating Temperature: -40°C; Max Operating Temperature: 105°C; Manufacturer Part Number: M20-7821046; Library Name: 28Pins.SchLib; Length: 25.8mm; Lead Pitch: 2.54mm; Insulation Material: Nylon; Insulation Color: Black; Housing Material: Nylon; Housing Color: Black; Flammability Rating: UL94 V-0; Fastening Type: Pull; Depth: 2.5mm; Current Rating: 3A; Contact Type: Female Socket; Contact Shape: Square; Contact Plating: Tin; Contact Material: Bronze; Contact Gender: Female; Contact Finish - Mating: TIN; Connector Type: Receptacle; ComponentLink4URL: https://datasheet.ciiva.com/10151/m20-782-10151366.pdf?src-supplier=Avnet; ComponentLink4Description: https://datasheet.ciiva.com/10151/m20-782-10151366.pdf?src-supplier=Avnet; ComponentLink3URL: https://datasheet.ciiva.com/6785/m20-782-6785605.pdf?src-supplier=Digikey; ComponentLink3Description: https://datasheet.ciiva.com/6785/m20-782-6785605.pdf?src-supplier=Digikey; ComponentLink2URL: https://datasheet.ciiva.com/26899/getdatasheetpartid-559226-26899379.pdf?src-supplier=Verical; ComponentLink2Description: https://datasheet.ciiva.com/26899/getdatasheetpartid-559226-26899379.pdf?src-supplier=Verical; ComponentLink1URL: https://datasheet.ciiva.com/11753/0900766b80dc1d2b-11753869.pdf?src-supplier=Rs; ComponentLink1Description: https://datasheet.ciiva.com/11753/0900766b80dc1d2b-11753869.pdf?src-supplier=Rs; Component Kind: Standard; Case/Package: SIL
J12 = M20-7820646: 2.54mm (0.1") Pitch SIL Vertical PC Tail Socket Assembly, tin, 6 contacts; Manufacturer: Harwin; Voltage Rating: 1kV; Number of Positions: 6; Mated Stacking Heights: 12.63mm; Gender: Female; Connector Type: Receptacle; Termination: Solder; Supplier Part Number 1: 952-1809-ND; Supplier 1: Digikey; Stock 1: 505; Series: M20; RoHS Compliant: Yes; RoHS: Yes; Radiation Hardening: No; Pricing 1: 1 = 1.24, 10 = 1.052, 100 = 0.9444, 500 = 0.77264, 1000 = 0.6868, 5000 = 0.60095, 10000 = 0.5709 (USD); Pitch: 2.54mm; Packaging: Rail/Tube; Package Quantity: 38; Number of Rows: 1; Number of Contacts: 6; Mount: Through Hole; Min Operating Temperature: -40°C; Max Operating Temperature: 105°C; Manufacturer Part Number: M20-7820646; Library Name: 28Pins.SchLib; Insulation Material: Nylon; Insulation Color: Black; Flammability Rating: UL94 V-0; Fastening Type: Pull; Contact Type: Female Socket; Contact Shape: Square; Contact Plating: Tin; Contact Material: Bronze; Contact Gender: Female; Contact Finish - Mating: TIN; ComponentLink7URL: https://cdn.harwin.com/pdfs/Harwin_PC_Connectors_M20.pdf?src-supplier=TTI; ComponentLink7Description: https://cdn.harwin.com/pdfs/Harwin_PC_Connectors_M20.pdf?src-supplier=TTI; ComponentLink6URL: https://cdn.harwin.com/pdfs/Harwin_PC_Connectors_M20.pdf?src-supplier=Rs; ComponentLink6Description: https://cdn.harwin.com/pdfs/Harwin_PC_Connectors_M20.pdf?src-supplier=Rs; ComponentLink5URL: https://cdn.harwin.com/pdfs/M20-782.pdf?src-supplier=Newark; ComponentLink5Description: https://cdn.harwin.com/pdfs/M20-782.pdf?src-supplier=Newark; ComponentLink4URL: https://cdn.harwin.com/pdfs/Harwin_PC_Connectors_M20.pdf?src-supplier=Mouser; ComponentLink4Description: https://cdn.harwin.com/pdfs/Harwin_PC_Connectors_M20.pdf?src-supplier=Mouser; ComponentLink3URL: https://cdn.harwin.com/pdfs/M20-782.pdf?src-supplier=Digikey; ComponentLink3Description: https://cdn.harwin.com/pdfs/M20-782.pdf?src-supplier=Digikey; ComponentLink2URL: http://www.harwin.com/include/downloads/pdfs/2010PAGE120.PDF?src-supplier=Avnet; ComponentLink2Description: http://www.harwin.com/include/downloads/pdfs/2010PAGE120.PDF?src-supplier=Avnet; ComponentLink1URL: https://cdn.harwin.com/pdfs/M20-782.pdf?src-supplier=Farnell; ComponentLink1Description: https://cdn.harwin.com/pdfs/M20-782.pdf?src-supplier=Farnell; Component Kind: Standard; Case/Package: SIL
L1 = AOTA-B201206S2R2MT: Manufacturer: Abracon; Supplier Part Number 1: 535-AOTA-B201206S2R2MTCT-ND; Supplier 1: Digikey; Stock 1: 3000; RoHS: No; Pricing 1: 1 = 0.35, 10 = 0.303, 25 = 0.2776, 50 = 0.2524, 100 = 0.2247, 250 = 0.21208, 500 = 0.1818, 1000 = 0.1616 (USD); Manufacturer Part Number: AOTA-B201206S2R2MT; Library Name: 28Pins.SchLib; Component Kind: Standard
LINK1-LINK3 = QPC02SXGN-RC: 2 Position 2.54 mm Centerline Single Circuit Open Top Jumper; Manufacturer: Sullins; Pitch: 2.54mm; Number of Positions: 2; Supplier Part Number 1: S9337-ND; Supplier 1: Digikey; Stock 1: 300412; Series: -; RoHS Compliant: Yes; RoHS: Yes; Pricing 1: 1 = 0.1, 10 = 0.05, 25 = 0.0416, 50 = 0.0382, 100 = 0.0369, 250 = 0.03184, 500 = 0.03058, 1000 = 0.02675, 2500 = 0.02421 (USD); Packaging: Bulk; Manufacturer Part Number: QPC02SXGN-RC; Library Name: 28Pins.SchLib; Lead Free: Lead Free; Housing Material: PBT; Flammability Rating: UL94 V-0; Contact Plating: Gold; ComponentLink1URL: https://datasheet.ciiva.com/767/284-sxgn-11510-767869.pdf?src-supplier=Digikey; ComponentLink1Description: https://datasheet.ciiva.com/767/284-sxgn-11510-767869.pdf?src-supplier=Digikey; Color: Black; Case/Package: 100
MH1-MH3 = MH_7.4x3.2: Mounting hole 7.4mm diameter 3.2mm drill hole; Library Name: 28Pins.SchLib
MH4 = MHNP_7.4x3.2_1: Mounting hole not plated 7.4mm diameter 3.2mm drill hole; Library Name: 28Pins.SchLib
R1 R2 R18 R20 R25 = RC0805FR-07560RL: Res General Purpose Thick Film 0805 560 Ohm 1% 1/8W ±100ppm/°C Molded Paper T/R; Manufacturer: Yageo; Voltage Rating: 150V; Power Rating: 125mW; Width: 0.049inch; Weight: 0.000194oz; Tolerance: 1%; Termination: SMD/SMT; Temperature Coefficient: 100ppm/°C; Supplier Part Number 1: 311-560CRCT-ND; Supplier 1: Digikey; Stock 1: 115458; Size Code: 0805; Series: RC; RoHS Compliant: Yes; RoHS: Yes; Resistor Type: Thick Film; Resistance Tolerance: 1%; Resistance: 560R; Reach SVHC Compliant: Unknown; Pricing 1: 1 = 0.1, 10 = 0.025, 50 = 0.016, 100 = 0.0133, 500 = 0.00912, 1000 = 0.00787 (USD); Packaging: Cut Tape; Package Quantity: 1; Number of Terminations: 2; Min Operating Temperature: -55°C; Max Power Dissipation: 125mW; Max Operating Temperature: 155°C; Manufacturer Part Number: RC0805FR-07560RL; Library Name: 28Pins.SchLib; Length: 0.079inch; Lead Free: Lead Free; Height: 0.024inch; Features: Moisture Resistant; ComponentLink6URL: https://datasheet.ciiva.com/7941/444164-7941814.pdf?src-supplier=Element14; ComponentLink6Description: https://datasheet.ciiva.com/7941/444164-7941814.pdf?src-supplier=Element14; ComponentLink5URL: https://datasheet.ciiva.com/7941/444164-7941813.pdf?src-supplier=Element14; ComponentLink5Description: https://datasheet.ciiva.com/7941/444164-7941813.pdf?src-supplier=Element14; ComponentLink4URL: https://datasheet.ciiva.com/5561/rc0805-5561861.pdf?src-supplier=Mouser; ComponentLink4Description: https://datasheet.ciiva.com/5561/rc0805-5561861.pdf?src-supplier=Mouser; ComponentLink3URL: https://datasheet.ciiva.com/2457/pyu-r-int-thick-7-2457142.pdf?src-supplier=Digikey; ComponentLink3Description: https://datasheet.ciiva.com/2457/pyu-r-int-thick-7-2457142.pdf?src-supplier=Digikey; ComponentLink2URL: https://datasheet.ciiva.com/2457/pyu-r-int-thick-7-2457141.pdf?src-supplier=Digikey; ComponentLink2Description: https://datasheet.ciiva.com/2457/pyu-r-int-thick-7-2457141.pdf?src-supplier=Digikey; ComponentLink1URL: https://datasheet.ciiva.com/2457/pyu-r-int-thick-7-2457140.pdf?src-supplier=Digikey; ComponentLink1Description: https://datasheet.ciiva.com/2457/pyu-r-int-thick-7-2457140.pdf?src-supplier=Digikey; Component Kind: Standard; Case Code (Metric): 2012; Case Code (Imperial): 0805; Case/Package: 0805
R3 = RC0805FR-07100KL: SMD Chip Resistor, 100 kOhm, ± 1%, 125 mW, 0805 [2012 Metric], Thick Film, General Purpose; Manufacturer: Yageo; Voltage Rating (DC): 150V; Temperature Coefficient: 100ppm/°C; Resistance: 100kR; Power Rating: 125mW; Width: 0.049inch; Voltage Rating (AC): 150V; Voltage Rating: 150V; Tolerance: 1%; Termination: SMD/SMT; Technology: THICK FILM; Supplier Part Number 1: 311-100KCRCT-ND; Supplier 1: Digikey; Stock 1: 2925189; Size Code: 0805; Series: RC; RoHS Compliant: Yes; RoHS: Yes; Resistor Type: Thick Film; Resistance Tolerance: 1%; Reach SVHC Compliant: Unknown; Pricing 1: 1 = 0.1, 10 = 0.025, 50 = 0.016, 100 = 0.0133, 500 = 0.00912, 1000 = 0.00787 (USD); Packaging: Tape and Reel; Package Quantity: 1; Number of Terminations: 2; Number of Pins: 2; Min Operating Temperature: -55°C; Military Standard: Not; Max Power Dissipation: 125mW; Max Operating Temperature: 155°C; Manufacturer Part Number: RC0805FR-07100KL; Library Name: 28Pins.SchLib; Length: 0.079inch; Lead Pitch: 0.4mm; Lead Free: 5697LeadFree; Height: 0.024inch; Features: Moisture Resistant; Depth: 1.25mm; Construction: Rectangular; ComponentLink11URL: https://datasheet.ciiva.com/16747/rc0805-16747226.pdf?src-supplier=Future+Electronics; ComponentLink11Description: https://datasheet.ciiva.com/16747/rc0805-16747226.pdf?src-supplier=Future+Electronics; ComponentLink10URL: https://datasheet.ciiva.com/7941/1697719-7941678.pdf?src-supplier=Element14; ComponentLink10Description: https://datasheet.ciiva.com/7941/1697719-7941678.pdf?src-supplier=Element14; ComponentLink9URL: https://datasheet.ciiva.com/7941/1697719-7941676.pdf?src-supplier=Element14; ComponentLink9Description: https://datasheet.ciiva.com/7941/1697719-7941676.pdf?src-supplier=Element14; ComponentLink8URL: https://datasheet.ciiva.com/12667/rc0805-12667001.pdf?src-supplier=Mouser; ComponentLink8Description: https://datasheet.ciiva.com/12667/rc0805-12667001.pdf?src-supplier=Mouser; ComponentLink7URL: https://datasheet.ciiva.com/2457/pyu-r-int-thick-7-2457017.pdf?src-supplier=Digikey; ComponentLink7Description: https://datasheet.ciiva.com/2457/pyu-r-int-thick-7-2457017.pdf?src-supplier=Digikey; ComponentLink6URL: https://datasheet.ciiva.com/2457/pyu-r-int-thick-7-2457019.pdf?src-supplier=Digikey; ComponentLink6Description: https://datasheet.ciiva.com/2457/pyu-r-int-thick-7-2457019.pdf?src-supplier=Digikey; ComponentLink5URL: https://datasheet.ciiva.com/2457/pyu-r-int-thick-7-2457018.pdf?src-supplier=Digikey; ComponentLink5Description: https://datasheet.ciiva.com/2457/pyu-r-int-thick-7-2457018.pdf?src-supplier=Digikey; ComponentLink4URL: https://datasheet.ciiva.com/22747/444164-22747534.pdf?src-supplier=Element14; ComponentLink4Description: https://datasheet.ciiva.com/22747/444164-22747534.pdf?src-supplier=Element14; ComponentLink3URL: https://datasheet.ciiva.com/7660/38627-7660796.pdf?src-supplier=Element14; ComponentLink3Description: https://datasheet.ciiva.com/7660/38627-7660796.pdf?src-supplier=Element14; ComponentLink2URL: https://datasheet.ciiva.com/7941/1686128-7941677.pdf?src-supplier=Element14; ComponentLink2Description: https://datasheet.ciiva.com/7941/1686128-7941677.pdf?src-supplier=Element14; ComponentLink1URL: https://datasheet.ciiva.com/11808/0900766b8106beab-11808858.pdf?src-supplier=Rs; ComponentLink1Description: https://datasheet.ciiva.com/11808/0900766b8106beab-11808858.pdf?src-supplier=Rs; Component Kind: Standard; Case Code (Metric): 2012; Case Code (Imperial): 0805; Case/Package: 0805
R4 R9 R14 R15 = RC0805JR-070RL: Zero Ohm Resistor, Jumper, 0805 [2012 Metric], Thick Film, 125 mW, 2 A, Surface Mount; Manufacturer: Yageo; Voltage Rating (DC): 150V; Tolerance: 5%; Temperature Coefficient: 200ppm/°C; Resistance: 0mR; Power Rating: 125mW; Width: 1.25mm; Voltage Rating: 150V; Termination: SMD/SMT; Technology: THICK FILM; Supplier Part Number 1: 311-0.0ARCT-ND; Supplier 1: Digikey; Stock 1: 541827; Size Code: 0805; Series: RC; RoHS Compliant: Yes; RoHS: Yes; Resistor Type: Thick Film; Resistance Tolerance: 5%; Reach SVHC Compliant: Unknown; Pricing 1: 1 = 0.1, 10 = 0.027, 25 = 0.0204, 50 = 0.0156, 100 = 0.0109, 250 = 0.00876, 500 = 0.00702, 1000 = 0.00517 (USD); Packaging: Tape and Reel; Number of Terminations: 2; Number of Pins: 2; Min Operating Temperature: -55°C; Military Standard: Not; Max Power Dissipation: 125mW; Max Operating Temperature: 155°C; Manufacturer Part Number: RC0805JR-070RL; Library Name: 28Pins.SchLib; Length: 2mm; Lead Free: 5697LeadFree; Height: 0.5mm; Features: Moisture Resistant; Depth: 1.25mm; Contact Plating: Tin,Matte; Construction: Rectangular; ComponentLink5URL: https://datasheet.ciiva.com/7941/1738694-7941863.pdf?src-supplier=Element14; ComponentLink5Description: https://datasheet.ciiva.com/7941/1738694-7941863.pdf?src-supplier=Element14; ComponentLink4URL: https://datasheet.ciiva.com/7941/1738694-7941862.pdf?src-supplier=Element14; ComponentLink4Description: https://datasheet.ciiva.com/7941/1738694-7941862.pdf?src-supplier=Element14; ComponentLink3URL: https://datasheet.ciiva.com/15190/getdatasheetpartid-330685-15190028.pdf?src-supplier=Verical; ComponentLink3Description: https://datasheet.ciiva.com/15190/getdatasheetpartid-330685-15190028.pdf?src-supplier=Verical; ComponentLink2URL: https://datasheet.ciiva.com/7941/1738694-7941861.pdf?src-supplier=Element14; ComponentLink2Description: https://datasheet.ciiva.com/7941/1738694-7941861.pdf?src-supplier=Element14; ComponentLink1URL: https://datasheet.ciiva.com/11819/0900766b8106beab-11819430.pdf?src-supplier=Rs; ComponentLink1Description: https://datasheet.ciiva.com/11819/0900766b8106beab-11819430.pdf?src-supplier=Rs; Component Kind: Standard; Case Code (Metric): 2012; Case Code (Imperial): 0805; Case/Package: 0805
R5 R17 R21-R24 = RC0805FR-0710KL: SMD Chip Resistor, 10 kOhm, ± 1%, 125 mW, 0805 [2012 Metric], Thick Film, General Purpose; Manufacturer: Yageo; Voltage Rating (AC): 150V; Temperature Coefficient: 100ppm/°C; Resistance: 10kR; Power Rating: 125mW; Width: 0.049inch; Voltage Rating: 150V; Tolerance: 1%; Termination: SMD/SMT; Technology: THICK FILM; Supplier Part Number 1: 311-10.0KCRCT-ND; Supplier 1: Digikey; Stock 1: 4994648; Size Code: 0805; Series: RC; RoHS Compliant: Yes; RoHS: Yes; Resistor Type: Thick Film; Resistance Tolerance: 1%; Reach SVHC Compliant: Unknown; Pricing 1: 1 = 0.1, 10 = 0.027, 50 = 0.017, 100 = 0.0142, 500 = 0.00976, 1000 = 0.00844 (USD); Packaging: Tape and Reel; Package Quantity: 1; Number of Terminations: 2; Min Operating Temperature: -55°C; Military Standard: Not; Max Power Dissipation: 125mW; Max Operating Temperature: 155°C; Manufacturer Part Number: RC0805FR-0710KL; Library Name: 28Pins.SchLib; Length: 0.079inch; Lead Free: Lead Free; Height: 0.024inch; Features: Moisture Resistant; Depth: 1.25mm; Construction: Rectangular; Component Kind: Standard; Case Code (Metric): 2012; Case Code (Imperial): 0805; Case/Package: 0805
R6-R8 R16 R26-R28 = RC0805FR-0722RL: SMD Chip Resistor, 22 Ohm, ± 1%, 125 mW, 0805 [2012 Metric], Thick Film, General Purpose; Manufacturer: Yageo; Voltage Rating (AC): 150V; Temperature Coefficient: 100ppm/°C; Power Rating: 125mW; Width: 0.049inch; Voltage Rating: 150V; Tolerance: 1%; Termination: SMD/SMT; Technology: THICK FILM; Supplier Part Number 1: 311-22.0CRDKR-ND; Supplier 1: Digikey; Stock 1: 244964; Series: RC; RoHS Compliant: Yes; RoHS: Yes; Resistor Type: Thick Film; Resistance Tolerance: 1%; Resistance: 22R; Reach SVHC Compliant: Unknown; Pricing 1: 1 = 0.1, 10 = 0.025, 50 = 0.016, 100 = 0.0133, 500 = 0.00912, 1000 = 0.00787 (USD); Packaging: Tape and Reel; Package Quantity: 1; Number of Terminations: 2; Min Operating Temperature: -55°C; Military Standard: Not; Max Power Dissipation: 125mW; Max Operating Temperature: 155°C; Manufacturer Part Number: RC0805FR-0722RL; Library Name: 28Pins.SchLib; Length: 0.079inch; Lead Free: Lead Free; Height: 0.024inch; Features: Moisture Resistant; Depth: 1.25mm; Construction: Rectangular; ComponentLink6URL: https://datasheet.ciiva.com/12667/rc0805-12667371.pdf?src-supplier=Mouser; ComponentLink6Description: https://datasheet.ciiva.com/12667/rc0805-12667371.pdf?src-supplier=Mouser; ComponentLink5URL: https://datasheet.ciiva.com/2457/pyu-r-int-thick-7-2457046.pdf?src-supplier=Digikey; ComponentLink5Description: https://datasheet.ciiva.com/2457/pyu-r-int-thick-7-2457046.pdf?src-supplier=Digikey; ComponentLink4URL: https://datasheet.ciiva.com/2457/pyu-r-int-thick-7-2457045.pdf?src-supplier=Digikey; ComponentLink4Description: https://datasheet.ciiva.com/2457/pyu-r-int-thick-7-2457045.pdf?src-supplier=Digikey; ComponentLink3URL: https://datasheet.ciiva.com/2457/pyu-r-int-thick-7-2457044.pdf?src-supplier=Digikey; ComponentLink3Description: https://datasheet.ciiva.com/2457/pyu-r-int-thick-7-2457044.pdf?src-supplier=Digikey; ComponentLink2URL: https://datasheet.ciiva.com/46447/444164-46447574.pdf?src-supplier=Element14; ComponentLink2Description: https://datasheet.ciiva.com/46447/444164-46447574.pdf?src-supplier=Element14; ComponentLink1URL: https://datasheet.ciiva.com/11819/0900766b8106beab-11819837.pdf?src-supplier=Rs; ComponentLink1Description: https://datasheet.ciiva.com/11819/0900766b8106beab-11819837.pdf?src-supplier=Rs; Component Kind: Standard; Case Code (Metric): 2012; Case Code (Imperial): 0805; Case/Package: 0805
